# Altium schematic sheet Power.SchDoc(Power)
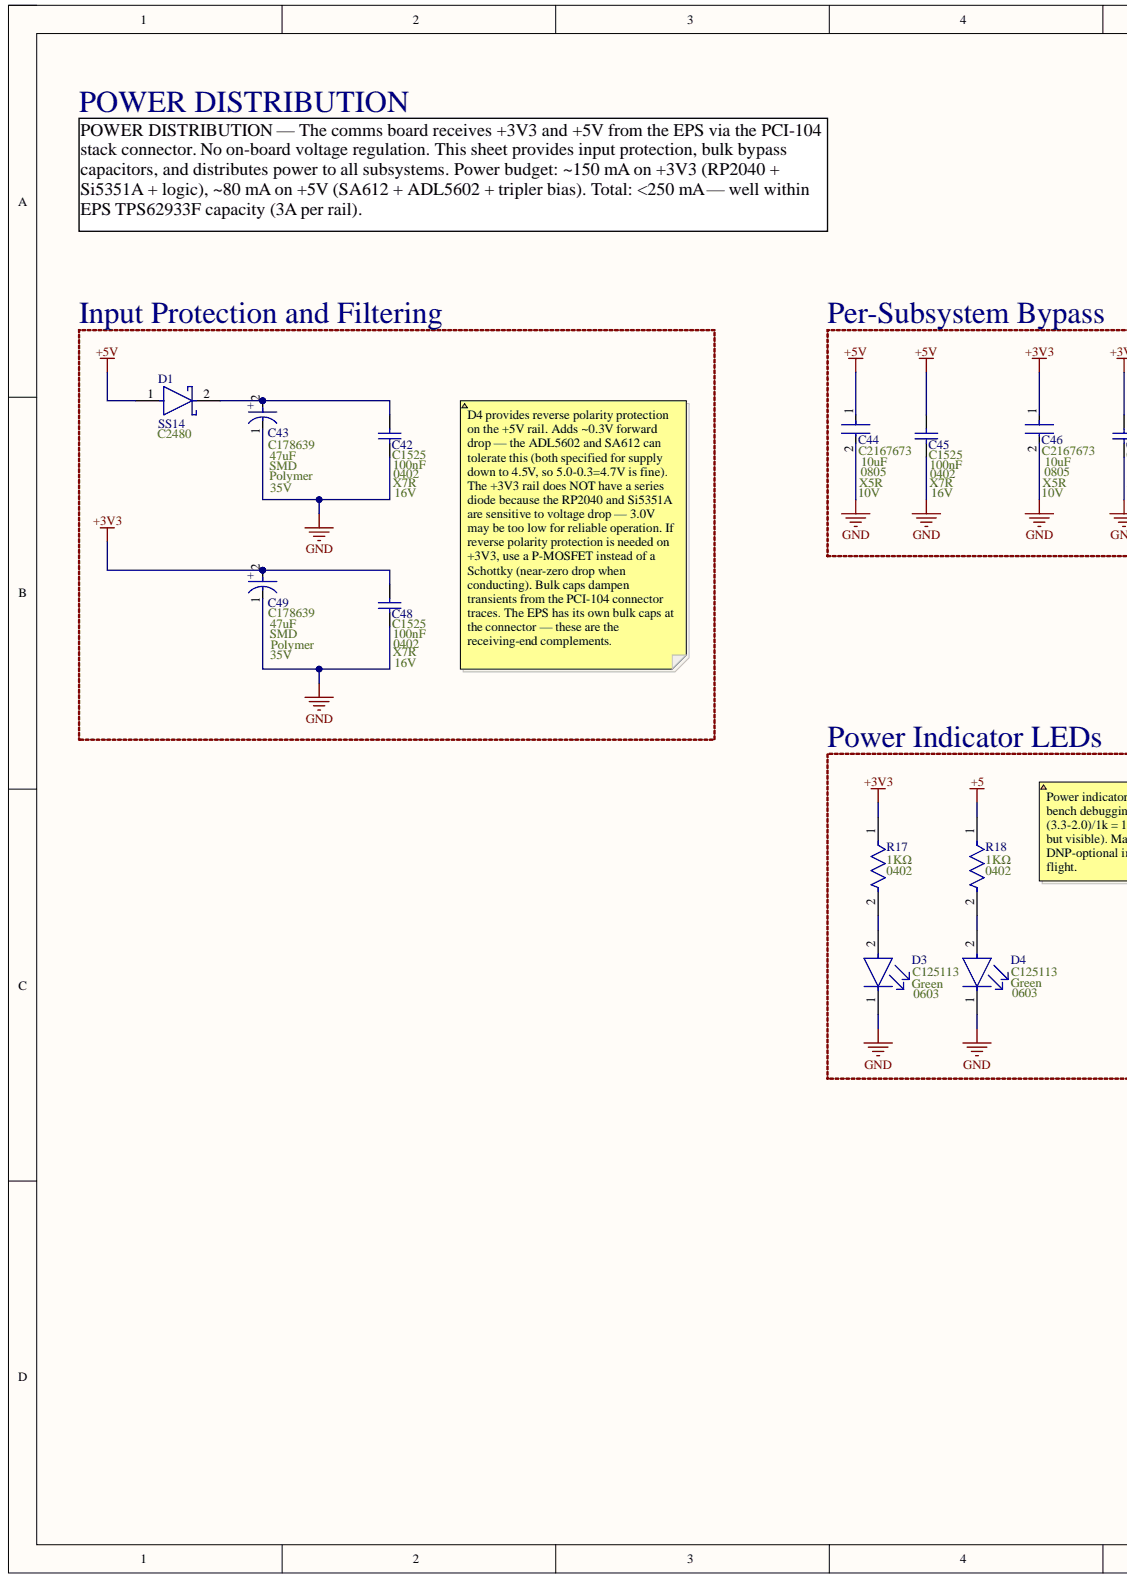
[diagram p0: Power.SchDoc, left]
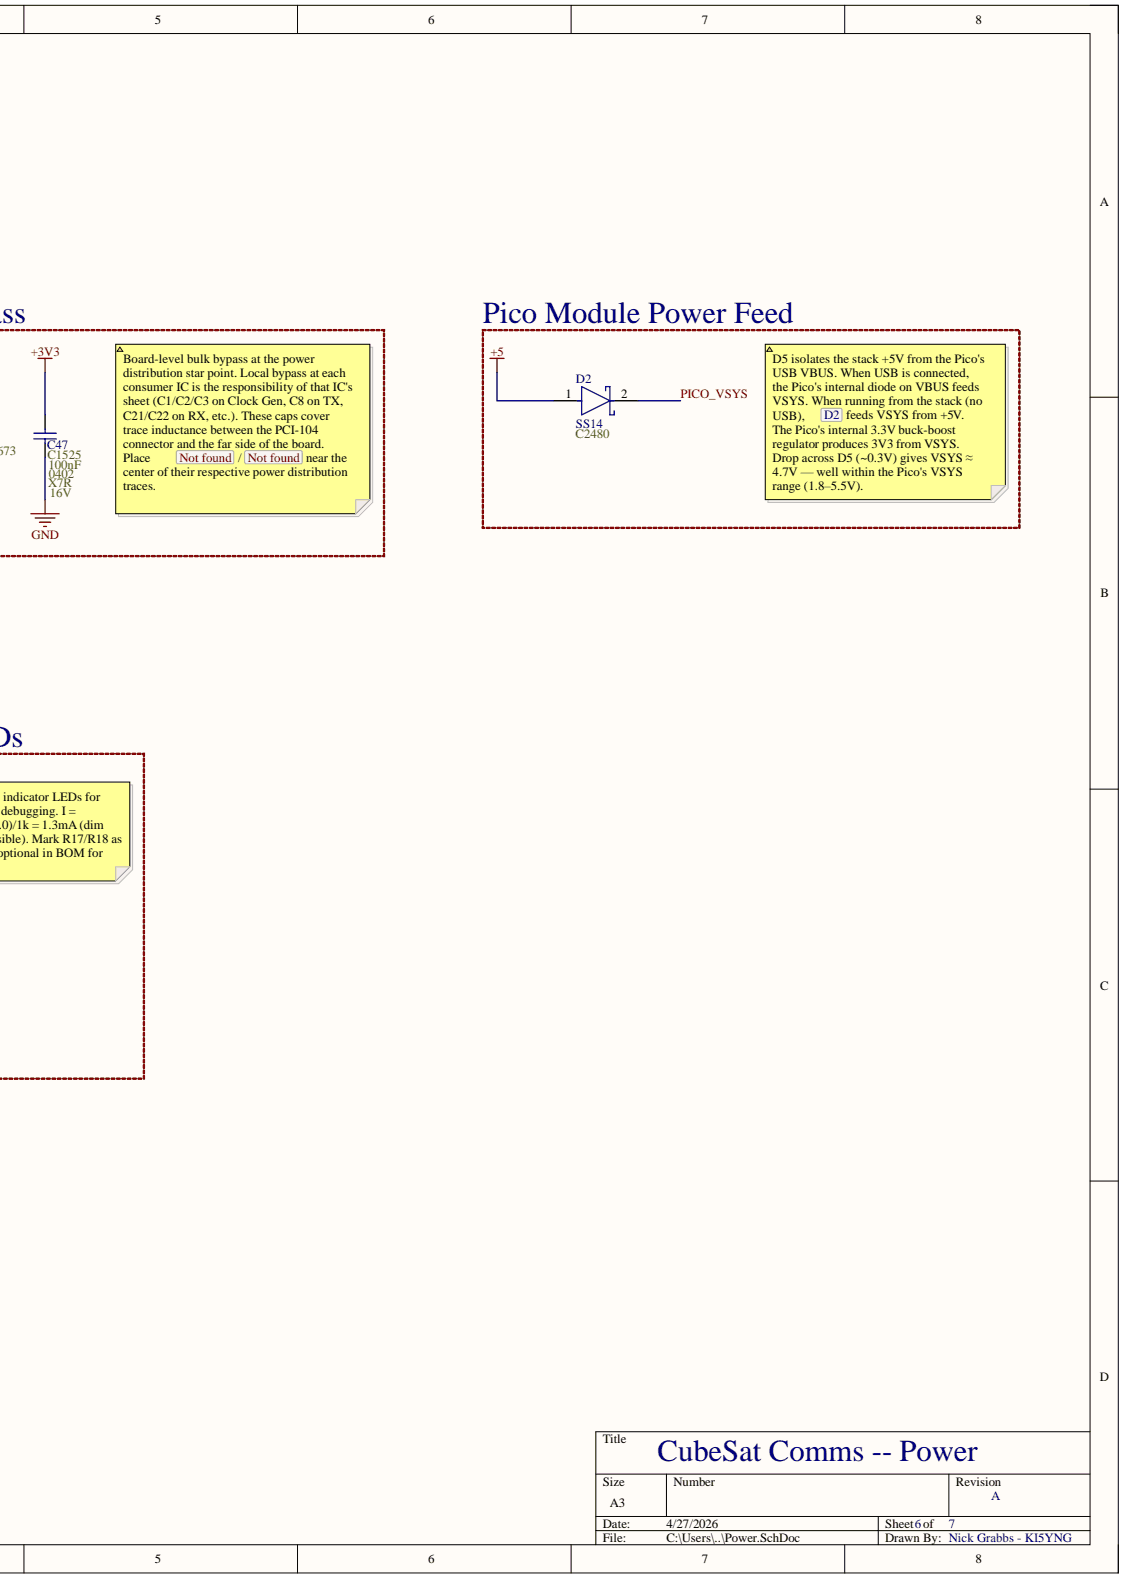
[diagram p1: Power.SchDoc, right]

## Parts
C42  Capacitance: 100nF  Case Size/Dimension: 0402  Dielectric: X7R  LCSC Part #: C1525  Rated Voltage: 16V  [CAPC1050X55X23ML05T13]  (hidden comment: CL05B104KO5NNNC)
C43  value 47uF  LCSC Part #: C178639  Package: SMD  [FP-EEH-D-MFG]  (hidden comment: EEHZA1V470P)
C44  value 10uF  Capacitance: 10uF  LCSC Part #: C2167673  Package: 0805  Temperature Characteristic: X5R  [FP-C0805C-DG-MFG]  (hidden comment: C0805C106K8PACTU)
C45  Capacitance: 100nF  Case Size/Dimension: 0402  Dielectric: X7R  LCSC Part #: C1525  Rated Voltage: 16V  [CAPC1050X55X23ML05T13]  (hidden comment: CL05B104KO5NNNC)
C46  value 10uF  Capacitance: 10uF  LCSC Part #: C2167673  Package: 0805  Temperature Characteristic: X5R  [FP-C0805C-DG-MFG]  (hidden comment: C0805C106K8PACTU)
C47  Capacitance: 100nF  Case Size/Dimension: 0402  Dielectric: X7R  LCSC Part #: C1525  Rated Voltage: 16V  [CAPC1050X55X23ML05T13]  (hidden comment: CL05B104KO5NNNC)
C48  Capacitance: 100nF  Case Size/Dimension: 0402  Dielectric: X7R  LCSC Part #: C1525  Rated Voltage: 16V  [CAPC1050X55X23ML05T13]  (hidden comment: CL05B104KO5NNNC)
C49  value 47uF  LCSC Part #: C178639  Package: SMD  [FP-EEH-D-MFG]  (hidden comment: EEHZA1V470P)
D1  SS14  LCSC Part #: C2480  [FP-403AE-MFG]
D2  SS14  LCSC Part #: C2480  [FP-403AE-MFG]
D3  Case Code (Imperial): 0603  Color: Green  LCSC Part #: C125113  [FP-LTST-S270KGKT-MFG]  (hidden comment: LTST-S270KGKT)
D4  Case Code (Imperial): 0603  Color: Green  LCSC Part #: C125113  [FP-LTST-S270KGKT-MFG]  (hidden comment: LTST-S270KGKT)
R17  value 1KΩ  Package: 0402  Resistance: 1KΩ  [FP-CRGCQ0402-IPC_C]  (hidden comment: CRGCQ0402F1K0)
R18  value 1KΩ  Package: 0402  Resistance: 1KΩ  [FP-CRGCQ0402-IPC_C]  (hidden comment: CRGCQ0402F1K0)

## Nets
+3V3: C46.1 C47.1 C48.1 C49.2 R17.1
+5: D2.1 R18.1
+5V: C44.1 C45.1 D1.1
GND: C42.2 C43.1 C44.2 C45.2 C46.2 C47.2 C48.2 C49.1 D3.1 D4.1
PICO_VSYS: D2.2
NetC42_1: C42.1 C43.2 D1.2
NetD3_2: D3.2 R17.2
NetD4_2: D4.2 R18.2

## Library data of the parts
C42 C45 C47 C48 = CMP-2000-05439-1: Chip Capacitor, 100 nF, +/- 10%, 16 V, -55 to 125 degC, 0402 (1005 Metric), RoHS, Tape and Reel; Manufacturer: Samsung Electro-Mechanics; Component Kind: Standard; Datasheet URL: https://datasheet.ciiva.com/29198/458718-da-01-en-vs-kondensator-0402-29198423.pdf; Datasheet Version: Nov-10; Library Name: Altium Content Vault; Manufacturer URL: http://www.samsungsem.com/global/index.jsp; Tolerance (%): ±10%
C43 C49 = CMP-05428-000653-1: Aluminum Hybrid Polymer Capacitors 47uF 20% 35V Life 10000Hours AEC-Q200 RADIAL SMT; Component Kind: Standard; Library Name: Altium Content Vault; Type: Polymer; Voltage: 35V
C44 C46 = CMP-2007-03243-2: CAP CER 10UF 10V X5R 0805; 3DS Part Number: 220-00001; Manufacturer: Kemet; Material: Ceramic; Temperature Coefficient: 15%; Tolerance: 10%; Automotive: No; Category: Cap; Component Kind: Standard; ComponentLink2Description: Datasheet; ComponentLink2URL: https://content.kemet.com/datasheets/KEM_C1006_X5R_SMD.pdf; Device Class L1: Passive Components; Device Class L2: Capacitors; Device Class L3: Ceramic Capacitors; Digikey Description: CAP CER 10UF 10V X5R 0805; Height: 1.4mm; Ipc Land Pattern Name: CAPC200125X125; Lead Free: Yes; Library Name: Altium Content Vault; Manufacturer Part Number: C0805C106K8PACTU; Mouser Description: CAP CER 10UF 10V X5R 0805; Octopart: https://octopart.com/c0805c106k8pactu-kemet-98697; RoHS: TRUE; Temperature: -55°C to +85°C; Temperature Range High: +85°C; Temperature Range Low: -55°C; Voltage: 10V; Voltage Rating: 10V
D1 D2 = CMP-2000-04943-2: DIODE SCHOTTKY 40V 1A SMA; Manufacturer: ON Semiconductor; Max Junction Temp: +125°C; Max Surge Forward Current: 40A; Peak Reverse Current: 0.2mA; Standoff Height: 0.05mm; Automotive: No; Category: Diode; Component Kind: Standard; ComponentLink2Description: Datasheet; ComponentLink2URL: https://www.onsemi.com/pub/Collateral/SS19-D.PDF; Device Class L1: Discrete Semiconductors; Device Class L2: Diodes; Device Class L3: Schottky Diodes; Digikey Description: DIODE SCHOTTKY 40V 1A SMA; Forward Current: 1A; Height: 2.5mm; Lead Free: Yes; Library Name: Altium Content Vault; Manufacturer Part Number: SS14; Max Repetitive Reverse Voltage: 40V; Mouser Description: Schottky Diodes & Rectifiers SMA 40V 1.0A; Octopart: https://octopart.com/ss14-on+semiconductor-29205033; Package: 403AE; RoHS: TRUE; Temperature: -65°C to +125°C; Temperature Range High: +125°C; Temperature Range Low: -65°C
D3 D4 = CMP-2000-06629-2: LED GREEN CLEAR CHIP SMD R/A; Dominant Wavelength: 571nm; Forward Current: 30mA; Forward Voltage: 2V; Lens Color: Colorless; Lens Style: Diffused; Luminous Intensity: 71mcd; Number of Elements: 1; Power Dissipation: 75mW; Reverse Voltage: 5V; Test Current: 20mA; Viewing Angle: 130°; Wavelength: 574nm; Case Code (Metric): 1608; Case/Package: 0603; Component Kind: Standard; Depth: 0.6mm; Height: 0.6mm; Illumination Color: Green; Lead Free: Lead Free; Length: 1.6mm; Lens Transparency: Clear; Library Name: Altium Content Vault; Max Operating Temperature: 85°C; Min Operating Temperature: -55°C; Mount: Surface Mount; Number of LEDs: 1; Number of Pins: 2; Package Quantity: 1; Packaging: Tape and Reel; Power Rating: 75mW; Radiation Hardening: No; Width: 1.15mm
R17 R18 = CMP-03211-006314-1: CRGCQ0402 1KΩ 1% ±100ppm/°C 50V; Manufacturer: TE Connectivity; Power Rating: 0.0625W; Tolerance: 0.01; Ambient Temperature Range High: +70°C; Automotive: Yes; Category: Res; Component Kind: Standard; ComponentLink2Description: Datasheet; ComponentLink2URL: https://www.te.com/commerce/DocumentDelivery/DDEController?Action=showdoc&DocId=Data+Sheet%7F1773204-3%7F1%7Fpdf%7FEnglish%7FENG_DS_1773204-3_1.pdf%7F4-2176347-694; Composition: Thick Film; Device Class L1: Passive Components; Device Class L2: Resistors; Device Class L3: Chip SMD Resistors; Height: 0.4mm; Ipc Land Pattern Name: RESC10050X35; Lead Free: Yes; Library Name: Altium Content Vault; Manufacturer Part Number: CRGCQ0402F1K0; Octopart: https://octopart.com/crgcq0402f1k0-te+connectivity-91017946; RoHS: TRUE; Series: CRGCQ; Temperature: -55°C to +155°C; Temperature Coefficient: ±100ppm/°C; Temperature Range High: +155°C; Temperature Range Low: -55°C; Voltage Rating: 50V
